# Altium schematic sheet LoRa transceiver_NOswitch.SchDoc("LoRa transceiver_NOswitch") (continued)

## Library data of the parts
A1 = MCH_000128: MCH SMT EMI Shield frame, 26.21mm X 26.21mm, 5.08 mm height; Manufacturer: Laird Technologies; ManufacturerID: Laird; Part Category: Mechanic/Shield/Solder mount socket; Part Select: SMCH0001001400010000; Supp Part number: 2497719; Supp Part number 2: 739-BMI-203-F; Active: 1; Cat1: Mechanic; Cat3: Solder mount socket; Database Table Name: _Mechanicals; Designer: Jiri Cengery; Exact price 3: 0; FIT: 0,100000001490116; Function Type: emi shielding; ID: 7920; LastRevisionNote: new release; Max Oper Temperature: 125; Min Oper Temperature: -40; PCB Status: Standard; Alternative: 1; Cat2: Shield; Component Kind: Standard; ComponentLink1Description: Datasheet; ComponentLink1URL: https://riceproject.fel.zcu.cz/Altium/PDF/Mechanic/Shields/1965028.pdf; Exact price: 1; Exact price 2: 1; LastRevisionDate: 19.8.2016; Library Name: Lib_Components_SQL.DbLib; Manuf Part Number: BMI-S-203-F; ManufacturerURL: http://www.lairdtech.com; Part Number: MCH_000128; Part type: MCH; PartNumber: MCH_000128; PartQuantity: 1; Preference: 0; Price: 42; Price 2: 19,5; Price 3: 0; Published: 19.8.2016; RoHS: 1; Simulation: nosim; Stock: 0; Supplier: Farnell; Supplier2: Mouser Electronics; Supplier3: N/A; SupplierID: Farnell; SupplierID2: Mouser; SupplierID3: no supplier; SupplierURL: http://cz.farnell.com/; SupplierURL2: http://www.mouser.com; SupplierURL3: N/A; Value: BMI-S-203-F; Publisher: Ludek Elis
A2 = MCH_000127: MCH EMI Cover Shield for BMI-S-203-F, 26.21mm X 26.21mm, 5.08 mm height,; Manufacturer: Laird Technologies; ManufacturerID: Laird; Part Category: Mechanic/Shield/Solder mount socket; Part Select: SMCH0001001400010000; Supp Part number: 2497718; Supp Part number 2: 739-BMI-203-C; Active: 1; Cat1: Mechanic; Cat3: Solder mount socket; Database Table Name: _Mechanicals; Designer: Jiri Cengery; Exact price 3: 0; FIT: 0,100000001490116; Function Type: emi shielding; ID: 7918; LastRevisionNote: new release; Max Oper Temperature: 125; Min Oper Temperature: -40; PCB Status: Mechanical; Alternative: 1; Cat2: Shield; Component Kind: Standard; ComponentLink1Description: Datasheet; ComponentLink1URL: https://riceproject.fel.zcu.cz/Altium/PDF/Mechanic/Shields/1965028.pdf; Exact price: 1; Exact price 2: 1; LastRevisionDate: 19.8.2016; Library Name: Lib_Components_SQL.DbLib; Manuf Part Number: BMI-S-203-C; ManufacturerURL: http://www.lairdtech.com; Part Number: MCH_000127; Part type: MCH; PartNumber: MCH_000127; PartQuantity: 1; Preference: 0; Price: 26,2000007629395; Price 2: 16; Price 3: 0; Published: 19.8.2016; RoHS: 1; Simulation: nosim; Stock: 0; Supplier: Farnell; Supplier2: Mouser Electronics; Supplier3: N/A; SupplierID: Farnell; SupplierID2: Mouser; SupplierID3: no supplier; SupplierURL: http://cz.farnell.com/; SupplierURL2: http://www.mouser.com; SupplierURL3: N/A; Value: BMI-S-203-C; Publisher: Ludek Elis
ANT_HF = CON_000512: CON SMA Female, pcb edge for panel, THT, 50 Ohm, 0 - 18 GHz; Manufacturer: Adam Tech; Part Select: SCON0008000000001500; Supp Part number: RF2145AT1750GHDW; Active: 1; Cat1: VF; Database Table Name: _Connectors; Design: SMA Female  edge mount; Designer: Jiri Cengery; Exact price 2: 0; ID: 7540; LastRevisionNote: new release; Max Oper Temperature: 165; Min Oper Temperature: -65; PCB Status: Electrical; Alternative: 1; Component Kind: Standard; ComponentLink1Description: Datasheet; ComponentLink1URL: https://riceproject.fel.zcu.cz/Altium/PDF/Passive/Connectors/RF2-145A-T-17-50-G-HDW.pdf; ComponentLink2Description: SMA connector; Exact price: 1; Exact price 3: 0; FIT: 0; LastRevisionDate: 11.11.2013; Library Name: Lib_Components_SQL.DbLib; Manuf Part Number: RF2-145A-T-17-50-G-HDW; ManufacturerID: Adam Tech; ManufacturerURL: http://www.adam-tech.com/index.php; Part Category: VF; Part Number: CON_000512; Part type: CON; PartNumber: CON_000512; PartQuantity: 1; Pin: 5; Preference: 0; Price: 33; Price 2: 0; Price 3: 0; Published: 29.5.2013; RoHS: 1; Simulation: nosim; Stock: 0; Supplier: TME; Supplier2: N/A; Supplier3: N/A; SupplierID: TME; SupplierID2: no supplier; SupplierID3: no supplier; SupplierURL: http://www.tme.eu/cz/; SupplierURL2: N/A; SupplierURL3: N/A; Value: RF2-145A-T-17-50-G-HDW; Publisher: Jiri Svarny
B1 = OSC_000032: Crystal - 32MHz; 10ppm, 10 pF, 80 Ohm;1.6 x 2 mm; Manuf Part Number: Q22FA12800530 FA-128 32MHZ 10PF; Manufacturer: CTS Corporation; ManufacturerID: CTS; Part Select: SOSC0003000000008320; Supp Part number: 2723228; Active: 1; Database Table Name: _Oscillators; Designer: Jiri  Cengery; Exact price 3: 0; FIT: 5; ID: 5074; LasrRevisionNote: new release; Max Oper Temperature: 70; Min Oper Temperature: -45; PCB Status: Electrical; Alternative: 1; Cat1: Crystal; Component Kind: Standard; ComponentLink1Description: Datasheet; ComponentLink1URL: https://riceproject.fel.zcu.cz/Altium/PDF/Passive/Crystal/CTS_402.pdf; Exact price: 1; Exact price 2: 1; LastRevisionDate: 17.4.2013; Library Name: Lib_Components_SQL.DbLib; ManufacturerURL: http://www.ctscorp.com/default.htm; Part Category: Crystal; Part Number: OSC_000032; Part type: OSC; PartNumber: OSC_000032; PartQuantity: 1; Preference: 0; Price: 25; Price 2: 0; Price 3: 0; Publishied: 17.4.2013; RoHS: 1; Simulation: nosim; Stock: 0; Supplier: Digikey; Supplier2: N/A; Supplier3: N/A; SupplierID: Farnell; SupplierID2: no supplier; SupplierID3: no supplier; SupplierURL: http://www.digikey.com/; SupplierURL2: N/A; SupplierURL3: N/A; Thermal Stability: 10ppm; Value: 32.00MHZ; Publisher: Ludek Elis
B2 = OSC_000031: Crystal - ABS07-32,768kHz; 12,5PF; SMD; MAX 70 kOhm; Manuf Part Number: ABS07-32.768KHZ-T; Manufacturer: Abracon; Part Select: SOSC0003000000005327; Supp Part number: 2101347; Active: 1; Database Table Name: _Oscillators; Designer: Jiri  Cengery; Exact price 3: 0; FIT: 5; ID: 4688; LasrRevisionNote: new release; Max Oper Temperature: 85; Min Oper Temperature: -45; PCB Status: Electrical; Thermal Stability: 20ppm; Alternative: 1; Cat1: Crystal; Component Kind: Standard; ComponentLink1Description: Datasheet; ComponentLink1URL: https://riceproject.fel.zcu.cz/Altium/PDF/Semiconductor/Logic/Oscilator/1883680.pdf; Exact price: 1; Exact price 2: 1; LastRevisionDate: 13.5.2011; Library Name: Lib_Components_SQL.DbLib; ManufacturerID: Abracon; ManufacturerURL: http://www.abracon.com/; Part Category: Crystal; Part Number: OSC_000031; Part type: OSC; PartNumber: OSC_000031; PartQuantity: 1; Preference: 0; Price: 15,1999998092651; Price 2: 0; Price 3: 0; Publishied: 13.5.2011; RoHS: 1; Simulation: nosim; Stock: 0; Supplier: Farnell; Supplier2: N/A; Supplier3: N/A; SupplierID: Farnell; SupplierID2: no supplier; SupplierID3: no supplier; SupplierURL: http://cz.farnell.com/; SupplierURL2: N/A; SupplierURL3: N/A; Value: 32,768kHz; Publisher: Libor Polacek
C1 C2 C27 C33 = CAP_000643: CAP CER 15pF 50V NPO 5% 0402; Manuf Part Number: CC0402JRNPO9BN150; Manufacturer: Yageo; Part Category: Ceramic/0402/COG/NPO; Part Select: SCAP0001000200012150; Supp Part number: 3019172; Active: 1; Cat1: Ceramic; Cat2: 0402; Cat3: COG/NPO; Database Table Name: _Capacitors; Designer: Jiri Cengery; Exact price 2: 0; FIT: 0,100000001490116; ID: 7910; LasrRevisionNote: new release; Max Oper Temperature: 125; Min Oper Temperature: -55; PCB Status: Electrical; Alternative: 1; Component Kind: Standard; ComponentLink1Description: Datasheet; ComponentLink1URL: https://riceproject.fel.zcu.cz/Altium/PDF/Passive/Capacitors/2213336.pdf; Dielectric: NPO; Exact price: 1; Exact price 3: 0; LastRevisionDate: 11.5.2011; Library Name: Lib_Components_SQL.DbLib; ManufacturerID: Yageo; ManufacturerURL: http://www.yageo.com/portal/index.jsp; Part Number: CAP_000643; Part type: CAP; PartNumber: CAP_000643; PartQuantity: 1; Preference: 0; Price: 0,519999980926514; Price 2: 0; Price 3: 0; Publishied: 11.5.2011; RoHS: 1; Simulation: cap; Stock: 0; Supplier: Farnell; Supplier2: N/A; Supplier3: N/A; SupplierID: Farnell; SupplierID2: no supplier; SupplierID3: no supplier; SupplierURL: http://cz.farnell.com/; SupplierURL2: N/A; SupplierURL3: N/A; Tolerance: 5%; Value: 15.0p; Voltage: 50V; Publisher: Libor Polacek
C3-C5 C20 C22 C25 C26 C28 C30-C32 = CAP_000417: CAP CER 100nF 25V X7R 10% 0402; Manuf Part Number: C1005X7R1E104K; Manufacturer: TDK Corporation; ManufacturerID: TDK; Part Category: Ceramic/0402/X7R; Part Select: SCAP0001000200026100; Supp Part number: 445-7348-1-ND; Active: 1; Cat1: Ceramic; Cat2: 0402; Database Table Name: _Capacitors; Designer: Libor Polacek; Exact price 2: 0; FIT: 0,100000001490116; ID: 2416; LasrRevisionNote: new release; Max Oper Temperature: 125; Min Oper Temperature: -55; PCB Status: Electrical; Preference: 100; Alternative: 1; Cat3: X7R; Component Kind: Standard; ComponentLink1Description: Datasheet; ComponentLink1URL: https://riceproject.fel.zcu.cz/Altium/PDF/passive/capacitors/Cxxxx_TDK.pdf; Dielectric: X7R; Exact price: 1; Exact price 3: 0; LastRevisionDate: 11.5.2011; Library Name: Lib_Components_SQL.DbLib; ManufacturerURL: http://www.tdk.com/; Part Number: CAP_000417; Part type: CAP; PartNumber: CAP_000417; PartQuantity: 1; PCB3D: sm_cap_0402; Price: 0,349999994039536; Price 2: 0; Price 3: 0; Publishied: 11.5.2011; RoHS: 1; Simulation: cap; Stock: 3064; Supplier: Digikey; Supplier2: N/A; Supplier3: N/A; SupplierID: Digikey; SupplierID2: no supplier; SupplierID3: no supplier; SupplierURL: http://www.digikey.com/; SupplierURL2: N/A; SupplierURL3: N/A; Tolerance: 10%; Value: 100n; Voltage: 25V; Publisher: Libor Polacek
C6 C29 C44 = CAP_000560: CAP CER 1.0uF 16V X7R 20% 0603; Manuf Part Number: 0603YC105MAT2A; Manufacturer: AVX; Part Category: Ceramic/0603/X7R; Part Select: SCAP0001000300027100; Supp Part number: 581-0603YC105MAT2A; Active: 1; Cat1: Ceramic; Cat2: 0603; Database Table Name: _Capacitors; Designer: Libor Polacek; Exact price 2: 0; FIT: 0,100000001490116; ID: 6238; LastRevisionNote: new release; Max Oper Temperature: 125; Min Oper Temperature: -55; PCB Status: Electrical; Alternative: 1; Cat3: X7R; Component Kind: Standard; ComponentLink1Description: Datasheet; ComponentLink1URL: https://riceproject.fel.zcu.cz/Altium/PDF/Passive/Capacitors/X7RDielectric-777024a.pdf; Dielectric: X7R; Exact price: 1; Exact price 3: 0; LastRevisionDate: 11.5.2011; Library Name: Lib_Components_SQL.DbLib; ManufacturerID: AVX; ManufacturerURL: http://www.avx.com/; Part Number: CAP_000560; Part type: CAP; PartNumber: CAP_000560; PartQuantity: 1; PCB3D: sm_cap_0603; Preference: 0; Price: 1,12000000476837; Price 2: 0; Price 3: 0; Published: 11.5.2011; RoHS: 1; Simulation: cap; Stock: 196; Supplier: Mouser Electronics; Supplier2: N/A; Supplier3: N/A; SupplierID: Mouser; SupplierID2: no supplier; SupplierID3: no supplier; SupplierURL: http://www.mouser.com; SupplierURL2: N/A; SupplierURL3: N/A; Tolerance: 20%; Value: 1.00u; Publisher: Libor Polacek
  C6 C29: Voltage: 16V
C7 C8 C16-C18 C21 = CAP_000513: CAP CER 47.0pF 50V COG 1% 0402; Manuf Part Number: GRM1555C1H470FA01D; Manufacturer: Murata; Part Category: Ceramic/0402/COG/NPO; Part Select: SCAP0001000200012220; Supp Part number: 490-6241-1-ND; Active: 1; Cat1: Ceramic; Cat2: 0402; Cat3: COG/NPO; Database Table Name: _Capacitors; Designer: Jiri  Cengery; Exact price 2: 0; FIT: 0,100000001490116; ID: 5076; LasrRevisionNote: new release; Max Oper Temperature: 125; Min Oper Temperature: -55; PCB Status: Electrical; Alternative: 1; Component Kind: Standard; ComponentLink1Description: Datasheet; ComponentLink1URL: https://riceproject.fel.zcu.cz/Altium/PDF/Passive/Capacitors/mlcc_commercial_general_en.pdf; Dielectric: COG; Exact price: 1; Exact price 3: 0; LastRevisionDate: 11.5.2011; Library Name: Lib_Components_SQL.DbLib; ManufacturerID: Murata; ManufacturerURL: http://www.murata.com/; Part Number: CAP_000513; Part type: CAP; PartNumber: CAP_000513; PartQuantity: 1; PCB3D: sm_cap_0402; Preference: 0; Price: 3; Price 2: 0; Price 3: 0; Publishied: 11.5.2011; RoHS: 1; Simulation: cap; Stock: 0; Supplier: Digikey; Supplier2: N/A; Supplier3: N/A; SupplierID: Digikey; SupplierID2: no supplier; SupplierID3: no supplier; SupplierURL: http://www.digikey.com/; SupplierURL2: N/A; SupplierURL3: N/A; Tolerance: 1%; Value: 47.0p; Voltage: 50V; Publisher: Libor Polacek
C9 = CAP_000642: CAP CER 33pF 50V NPO 5% 0402; Manuf Part Number: CC0402JRNPO9BN330; Manufacturer: Yageo; Part Category: Ceramic/0402/COG/NPO; Part Select: SCAP0001000200012330; Supp Part number: 3019196; Active: 1; Cat1: Ceramic; Cat2: 0402; Cat3: COG/NPO; Database Table Name: _Capacitors; Designer: Jiri Cengery; Exact price 2: 0; FIT: 0,100000001490116; ID: 7906; LasrRevisionNote: new release; Max Oper Temperature: 125; Min Oper Temperature: -55; PCB Status: Electrical; Alternative: 1; Component Kind: Standard; ComponentLink1Description: Datasheet; ComponentLink1URL: https://riceproject.fel.zcu.cz/Altium/PDF/Passive/Capacitors/2213336.pdf; Dielectric: NPO; Exact price: 1; Exact price 3: 0; LastRevisionDate: 11.5.2011; Library Name: Lib_Components_SQL.DbLib; ManufacturerID: Yageo; ManufacturerURL: http://www.yageo.com/portal/index.jsp; Part Number: CAP_000642; Part type: CAP; PartNumber: CAP_000642; PartQuantity: 1; Preference: 0; Price: 0,52999997138977; Price 2: 0; Price 3: 0; Publishied: 11.5.2011; RoHS: 1; Simulation: cap; Stock: 0; Supplier: Farnell; Supplier2: N/A; Supplier3: N/A; SupplierID: Farnell; SupplierID2: no supplier; SupplierID3: no supplier; SupplierURL: http://cz.farnell.com/; SupplierURL2: N/A; SupplierURL3: N/A; Tolerance: 5%; Value: 33.0p; Voltage: 50V; Publisher: Libor Polacek
C10 = CAP_000455: CAP CER 1.5pF 50V COG ±0.25pF 0402; Manuf Part Number: C1005C0G1H1R5C; Manufacturer: TDK Corporation; ManufacturerID: TDK; Part Category: Ceramic/0402/COG/NPO; Part Select: SCAP0001000200011150; Supp Part number: 445-4859-1-ND; Active: 1; Cat1: Ceramic; Cat2: 0402; Cat3: COG/NPO; Database Table Name: _Capacitors; Designer: Lukas Pusman; Exact price 2: 0; FIT: 0,100000001490116; ID: 3175; LasrRevisionNote: new release; Max Oper Temperature: 125; Min Oper Temperature: -55; PCB Status: Electrical; Preference: 100; Alternative: 1; Component Kind: Standard; ComponentLink1Description: Datasheet; ComponentLink1URL: https://riceproject.fel.zcu.cz/Altium/PDF/passive/capacitors/Cxxxx_TDK.pdf; Dielectric: COG; Exact price: 1; Exact price 3: 0; LastRevisionDate: 11.5.2011; Library Name: Lib_Components_SQL.DbLib; ManufacturerURL: http://www.tdk.com/; Part Number: CAP_000455; Part type: CAP; PartNumber: CAP_000455; PartQuantity: 1; PCB3D: sm_cap_0402; Price: 3; Price 2: 0; Price 3: 0; Publishied: 11.5.2011; RoHS: 1; Simulation: cap; Stock: 0; Supplier: Digikey; Supplier2: N/A; Supplier3: N/A; SupplierID: Digikey; SupplierID2: no supplier; SupplierID3: no supplier; SupplierURL: http://www.digikey.com/; SupplierURL2: N/A; SupplierURL3: N/A; Tolerance: ±0.25pF; Value: 1.5p; Voltage: 50V; Publisher: Libor Polacek
C11 C14 = CAP_000639: CAP CER 4.7pF 50V NPO ±0,25p 0402; Manuf Part Number: CC0402CRNPO9BN4R7; Manufacturer: Yageo; Part Category: Ceramic/0402/COG/NPO; Part Select: SCAP0001000200011470; Supp Part number: 3019147; Active: 1; Cat1: Ceramic; Cat2: 0402; Cat3: COG/NPO; Database Table Name: _Capacitors; Designer: Jiri Cengery; Exact price 2: 0; FIT: 0,100000001490116; ID: 7900; LasrRevisionNote: new release; Max Oper Temperature: 125; Min Oper Temperature: -55; PCB Status: Electrical; Tolerance: 0,25pF; Alternative: 1; Component Kind: Standard; ComponentLink1Description: Datasheet; ComponentLink1URL: https://riceproject.fel.zcu.cz/Altium/PDF/Passive/Capacitors/2213336.pdf; Dielectric: NPO; Exact price: 1; Exact price 3: 0; LastRevisionDate: 11.5.2011; Library Name: Lib_Components_SQL.DbLib; ManufacturerID: Yageo; ManufacturerURL: http://www.yageo.com/portal/index.jsp; Part Number: CAP_000639; Part type: CAP; PartNumber: CAP_000639; PartQuantity: 1; Preference: 0; Price: 0,439999997615814; Price 2: 0; Price 3: 0; Publishied: 11.5.2011; RoHS: 1; Simulation: cap; Stock: 0; Supplier: Farnell; Supplier2: N/A; Supplier3: N/A; SupplierID: Farnell; SupplierID2: no supplier; SupplierID3: no supplier; SupplierURL: http://cz.farnell.com/; SupplierURL2: N/A; SupplierURL3: N/A; Value: 4.70p; Voltage: 50V; Publisher: Libor Polacek
C12 = Cap_000641: CAP CER 1.2pF 50V NPO ± 0,25pF 0402; Manuf Part Number: CC0402CRNPO9BN1R2; Manufacturer: Yageo; Part Category: Ceramic/0402/COG/NPO; Part Select: SCAP0001000200011120; Supp Part number: 3369075; Active: 1; Cat1: Ceramic; Cat2: 0402; Cat3: COG/NPO; Database Table Name: _Capacitors; Designer: Jiri Cengery; Exact price 2: 0; FIT: 0,100000001490116; ID: 7904; LasrRevisionNote: new release; Max Oper Temperature: 125; Min Oper Temperature: -55; PCB Status: Electrical; Tolerance: 0,25pF; Alternative: 1; Component Kind: Standard; ComponentLink1Description: Datasheet; ComponentLink1URL: https://riceproject.fel.zcu.cz/Altium/PDF/Passive/Capacitors/2213336.pdf; Dielectric: NPO; Exact price: 1; Exact price 3: 0; LastRevisionDate: 11.5.2011; Library Name: Lib_Components_SQL.DbLib; ManufacturerID: Yageo; ManufacturerURL: http://www.yageo.com/portal/index.jsp; Part Number: CAP_000641; Part type: CAP; PartNumber: CAP_000641; PartQuantity: 1; Preference: 0; Price: 0,439999997615814; Price 2: 0; Price 3: 0; Publishied: 11.5.2011; RoHS: 1; Simulation: cap; Stock: 0; Supplier: Farnell; Supplier2: N/A; Supplier3: N/A; SupplierID: Farnell; SupplierID2: no supplier; SupplierID3: no supplier; SupplierURL: http://cz.farnell.com/; SupplierURL2: N/A; SupplierURL3: N/A; Value: 1.20p; Voltage: 50V; Publisher: Libor Polacek
C13 C15 = CAP_000640: CAP CER 1.8pF 50V NPO ± 0,25pF 0402; Manuf Part Number: CC0402CRNPO9BN1R8; Manufacturer: Yageo; Part Category: Ceramic/0402/COG/NPO; Part Select: SCAP0001000200011180; Supp Part number: 3369076; Active: 1; Cat1: Ceramic; Cat2: 0402; Cat3: COG/NPO; Database Table Name: _Capacitors; Designer: Jiri Cengery; Exact price 2: 0; FIT: 0,100000001490116; ID: 7902; LasrRevisionNote: new release; Max Oper Temperature: 125; Min Oper Temperature: -55; PCB Status: Electrical; Tolerance: 0,25pF; Alternative: 1; Component Kind: Standard; ComponentLink1Description: Datasheet; ComponentLink1URL: https://riceproject.fel.zcu.cz/Altium/PDF/Passive/Capacitors/2213336.pdf; Dielectric: NPO; Exact price: 1; Exact price 3: 0; LastRevisionDate: 11.5.2011; Library Name: Lib_Components_SQL.DbLib; ManufacturerID: Yageo; ManufacturerURL: http://www.yageo.com/portal/index.jsp; Part Number: CAP_000640; Part type: CAP; PartNumber: CAP_000640; PartQuantity: 1; Preference: 0; Price: 0,5799999833107; Price 2: 0; Price 3: 0; Publishied: 11.5.2011; RoHS: 1; Simulation: cap; Stock: 0; Supplier: Farnell; Supplier2: N/A; Supplier3: N/A; SupplierID: Farnell; SupplierID2: no supplier; SupplierID3: no supplier; SupplierURL: http://cz.farnell.com/; SupplierURL2: N/A; SupplierURL3: N/A; Value: 1.80p; Voltage: 50V; Publisher: Libor Polacek
C19 = CAP_000514: CAP CER 6.8pF 50V COG 0.5% 0402; Manuf Part Number: GCM1555C1H6R8DA16D; Manufacturer: Murata; Part Category: Ceramic/0402/COG/NPO; Part Select: SCAP0001000200012470; Supp Part number: 490-13293-1-ND; Active: 1; Cat1: Ceramic; Cat2: 0402; Cat3: COG/NPO; Database Table Name: _Capacitors; Designer: Jiri  Cengery; Exact price 2: 0; FIT: 0,100000001490116; ID: 5078; LasrRevisionNote: new release; Max Oper Temperature: 125; Min Oper Temperature: -55; PCB Status: Electrical; Alternative: 1; Component Kind: Standard; ComponentLink1Description: Datasheet; ComponentLink1URL: https://riceproject.fel.zcu.cz/Altium/PDF/Passive/Capacitors/c03e.pdf; Dielectric: COG; Exact price: 1; Exact price 3: 0; LastRevisionDate: 11.5.2011; Library Name: Lib_Components_SQL.DbLib; ManufacturerID: Murata; ManufacturerURL: http://www.murata.com/; Part Number: CAP_000514; Part type: CAP; PartNumber: CAP_000514; PartQuantity: 1; PCB3D: sm_cap_0402; Preference: 0; Price: 0; Price 2: 0; Price 3: 0; Publishied: 11.5.2011; RoHS: 1; Simulation: cap; Stock: 0; Supplier: Digikey; Supplier2: N/A; Supplier3: N/A; SupplierID: Digikey; SupplierID2: no supplier; SupplierID3: no supplier; SupplierURL: http://www.digikey.com/; SupplierURL2: N/A; SupplierURL3: N/A; Tolerance: 0.5%; Value: 6.8p; Voltage: 50V; Publisher: Libor Polacek
C23 C24 = CAP_000625: CAP CER 10.0u 10V X6S 20% 0603; Manuf Part Number: CL10X106MP8NRNC; Manufacturer: Samsung Electro-Mechanics; ManufacturerID: Samsung; Part Category: Ceramic/0603/X5R; Part Select: SCAP0001000300038100; Supp Part number: 1276-2383-1-ND; Active: 1; Cat1: Ceramic; Cat2: 0603; Cat3: X5R; Database Table Name: _Capacitors; Designer: Martin Jára; Exact price 2: 0; FIT: 0,100000001490116; ID: 7716; LasrRevisionNote: new release; Max Oper Temperature: 105; Min Oper Temperature: -55; PCB Status: Electrical; Alternative: 1; Component Kind: Standard; ComponentLink1Description: Datasheet; ComponentLink1URL: https://riceproject.fel.zcu.cz/Altium/PDF/Passive/Capacitors/MLCC(1).pdf; Dielectric: X6S; Exact price: 1; Exact price 3: 0; LastRevisionDate: 11.5.2011; Library Name: Lib_Components_SQL.DbLib; ManufacturerURL: http://www.samsungsem.com; Part Number: CAP_000625; Part type: CAP; PartNumber: CAP_000625; PartQuantity: 1; PCB3D: sm_cap_0603; Preference: 0; Price: 9; Price 2: 0; Price 3: 0; Publishied: 11.5.2011; RoHS: 1; Simulation: cap; Stock: 0; Supplier: Digikey; Supplier2: N/A; Supplier3: N/A; SupplierID: Digikey; SupplierID2: no supplier; SupplierID3: no supplier; SupplierURL: http://www.digikey.com/; SupplierURL2: N/A; SupplierURL3: N/A; Tolerance: 20%; Value: 10.0u; Voltage: 10V; Publisher: Libor Polacek
C36 = CAP_000450: CAP CER 10.0nF 25V X7R 10% 0402; Manuf Part Number: C1005X7R1E103K; Manufacturer: TDK Corporation; ManufacturerID: TDK; Part Category: Ceramic/0402/X7R; Part Select: SCAP0001000200026100; Supp Part number: 445-1260-1-ND; Active: 1; Cat1: Ceramic; Cat2: 0402; Database Table Name: _Capacitors; Designer: Libor Polacek; Exact price 2: 0; FIT: 0,100000001490116; ID: 3141; LasrRevisionNote: new release; Max Oper Temperature: 125; Min Oper Temperature: -55; PCB Status: Electrical; Preference: 100; Alternative: 1; Cat3: X7R; Component Kind: Standard; ComponentLink1Description: Datasheet; ComponentLink1URL: https://riceproject.fel.zcu.cz/Altium/PDF/passive/capacitors/Cxxxx_TDK.pdf; Dielectric: X7R; Exact price: 1; Exact price 3: 0; LastRevisionDate: 11.5.2011; Library Name: Lib_Components_SQL.DbLib; ManufacturerURL: http://www.tdk.com/; Part Number: CAP_000450; Part type: CAP; PartNumber: CAP_000450; PartQuantity: 1; PCB3D: sm_cap_0402; Price: 0,300000011920929; Price 2: 0; Price 3: 0; Publishied: 11.5.2011; RoHS: 1; Simulation: cap; Stock: 0; Supplier: Digikey; Supplier2: N/A; Supplier3: N/A; SupplierID: Digikey; SupplierID2: no supplier; SupplierID3: no supplier; SupplierURL: http://www.digikey.com/; SupplierURL2: N/A; SupplierURL3: N/A; Tolerance: 10%; Value: 10.0n; Voltage: 25V; Publisher: Libor Polacek
D1 D2 = OPT_000081: OPT LED red 625nm 2mA 50mcd 1.8V 0603; Manufacturer: kingbright; Part Category: LED/0603; Part Select: SOPT0001000100000000; Supp Part number: 2610411; Active: 1; Cat2: 0603; Database Table Name: _Optoelectronics; Designer: Jiri  Cengery; Exact price: 0; FIT: 2; ID: 6052; LastRevisionNote: new release; Max Oper Temperature: 85; Min Oper Temperature: -40; PCB Status: Electrical; Alternative: 1; Cat1: LED; Component Kind: Standard; ComponentLink1Description: Datasheet; ComponentLink1URL: https://riceproject.fel.zcu.cz/Altium/PDF/Semiconductor\Analog\LED\2068425.pdf; Exact price 2: 0; Exaxt price 3: 0; LastRevisionDate: 11.5.2011; Library Name: Lib_Components_SQL.DbLib; Manuf Part Number: KPTD-1608LVSECK-J3-PF; ManufacturerID: Kingbright; ManufacturerURL: www.kingbright.com; Part Number: OPT_000081; Part type: OPT; PartNumber: OPT_000081; PartQuantity: 1; PCB3D: sm_opt_led0603_h37_opp; Preference: 0; Price: 7; Price 2: 0; Price 3: 0; Published: 11.5.2011; Simulation: nosim; Stock: 0; Supplier: Farnell; Supplier2: N/A; Supplier3: N/A; SupplierID: Farnell; SupplierID2: no supplier; SupplierID3: no supplier; SupplierURL: http://cz.farnell.com/; SupplierURL2: N/A; SupplierURL3: N/A; Value: KPTD-1608LVSECK-J3-PF; Publisher: Libor Polacek
FID1-FID4 = MCH_000050: Fiducial local circle 0.8mm; Manuf Part Number: x; ManufacturerID: no manufacturer; Part Category: Virtual/Fiducial; Part Select: SMCH0002000200000000; Active: 1; Cat1: Virtual; Database Table Name: _Mechanicals; Designer: Libor Polacek; Exact price: 0; ID: 2608; LastRevisionNote: new release; Max Oper Temperature: 200; Min Oper Temperature: -60; Alternative: 1; Cat2: Fiducial; Component Kind: Standard; ComponentLink1Description: Datasheet; Exact price 2: 0; Exact price 3: 0; FIT: 0; Function Type: fiducial; LastRevisionDate: 8.9.2011; Library Name: Lib_Components_SQL.DbLib; Part Number: MCH_000050; Part type: MCH; PartNumber: MCH_000050; PartQuantity: 1; PCB3D: sm_vir_fiducial; PCB Status: Virtual; Preference: 0; Price: 0; Price 2: 0; Price 3: 0; Publishied: 8.9.2011; RoHS: 1; Simulation: nosim; Stock: 0; Supp Part number: x; Supplier: N/A; Supplier2: N/A; Supplier3: N/A; SupplierID: no supplier; SupplierID2: no supplier; SupplierID3: no supplier; SupplierURL: N/A; SupplierURL2: N/A; SupplierURL3: N/A; Value: Fiducial; Publisher: Libor Polacek
H1 = MCH_000066: Test 5 pins row pit 50; ManufacturerID: no manufacturer; Part Category: Virtual/Test point; Part Select: SMCH0002000100000000; Active: 1; Cat1: Virtual; Cat2: Test point; Database Table Name: _Mechanicals; Designer: Jiri  Cengery; Exact price 2: 0; FIT: 9,99999974737875E-6; Function Type: Test points; ID: 3861; LastRevisionNote: new release; Max Oper Temperature: 200; Min Oper Temperature: -60; Alternative: 1; ComponentLink1Description: Datasheet; Exact price: 1; Exact price 3: 0; LastRevisionDate: 29.2.2016; Library Name: Lib_Components_SQL.DbLib; Part Number: MCH_000066; Part type: MCH; PartNumber: MCH_000066; PartQuantity: 1; PCB Status: Virtual; Preference: 0; Price: 0; Price 2: 0; Price 3: 0; Publishied: 29.2.2016; RoHS: 1; Simulation: nosim; Stock: 0; Supplier: N/A; Supplier2: N/A; Supplier3: N/A; SupplierID: no supplier; SupplierID2: no supplier; SupplierID3: no supplier; SupplierURL: N/A; SupplierURL2: N/A; SupplierURL3: N/A; Value: TP40_5_pit50; Publisher: Jiri Cengery
H2 = CON_000361: CON Receptacle 1x10 100 mils, leads length 10mm; Manufacturer: SAMTEC; Part Category: Pin rows/Receptacle/long leads; Part Select: TCON0001000200022120; Supp Part number: 2283784; Active: 1; Cat1: Pin rows; Cat3: long leads; Database Table Name: _Connectors; Design: RECEPTACLE 100mill; Designer: Jiri  Cengery; Exact price 2: 0; FIT: 50; ID: 5578; Max Oper Temperature: 125; Min Oper Temperature: -55; PCB Status: Electrical; System: Connector; Alternative: 1; Cat2: Receptacle; Component Kind: Standard; ComponentLink1Description: Datasheet; ComponentLink1URL: https://riceproject.fel.zcu.cz/Altium/PDF/Passive\Connectors\Samtec\1680445_.pdf; Exact price: 1; Exaxt price 3: 0; LatestRevisionDate: 13.10.2017; LatestRevisionNote: New Release; Library Name: Lib_Components_SQL.DbLib; Manuf Part Number: SSQ-112-03-F-S; ManufacturerID: SAMTEC; ManufacturerURL: http://www.samtec.com/; Part Number: CON_000361; Part type: CON; PartNumber: CON_000361; PartQuantity: 1; PCB3D: TH_CON_REC1x12_PIT100_L400; Pin: 12; Preference: 0; Price: 54; Price 2: 0; Price 3: 0; Published: 13.10.2017; ROHS: 1; Signal Integrity: Connector; Simulation: nosim; Stock: 0; Supplier: Farnell; Supplier2: N/A; Supplier3: N/A; SupplierID: Farnell; SupplierID2: no supplier; SupplierID3: no supplier; SupplierURL: http://cz.farnell.com/; SupplierURL2: N/A; SupplierURL3: N/A; Value: SSQ-112-03-F-S; Publisher: Jiri Cengery
H3 = CON_000370: CON Receptacle 1x8 100 mils, hight 8.5mm; Manufacturer: MCM Electronics; ManufacturerID: Multicomp; Part Category: Pin rows/Receptacle; Part Select: TCON0001000200001800; Supp Part number: 1593463; Active: 1; Cat1: Pin rows; Database Table Name: _Connectors; Design: RECEPTACLE 100mill; Designer: Jiri  Cengery; Exact price 2: 0; FIT: 50; ID: 5624; Max Oper Temperature: 105; Min Oper Temperature: -55; PCB Status: Electrical; System: Connector; Alternative: 1; Cat2: Receptacle; Component Kind: Standard; ComponentLink1Description: Datasheet; ComponentLink1URL: https://riceproject.fel.zcu.cz/Altium/PDF/Passive\Connectors\2290158.pdf; Exact price: 1; Exaxt price 3: 0; LatestRevisionDate: 13.10.2017; LatestRevisionNote: New Release; Library Name: Lib_Components_SQL.DbLib; Manuf Part Number: 2212S-08SG-85; ManufacturerURL: http://www.mcmelectronics.com; Part Number: CON_000370; Part type: CON; PartNumber: CON_000370; PartQuantity: 1; PCB3D: TH_CON_REC1x8_PIT100; Pin: 8; Preference: 0; Price: 23; Price 2: 0; Price 3: 0; Published: 13.10.2017; ROHS: 1; Signal Integrity: Connector; Simulation: nosim; Stock: 0; Supplier: Farnell; Supplier2: N/A; Supplier3: N/A; SupplierID: Farnell; SupplierID2: no supplier; SupplierID3: no supplier; SupplierURL: http://cz.farnell.com/; SupplierURL2: N/A; SupplierURL3: N/A; Value: 2212S-08SG-85; Publisher: Jiri Cengery
L1 = EMI_000000: EMI 0603 1000R/ 100MHz 300mA 0,6R; Manuf Part Number: 742792664; Manufacturer: Würth Elektronik; ManufacturerID: Wurth; Part Select: SEMI0001000000003000; Supp Part number: 732-1586-2-ND; Active: 1; Cat1: Inductive; Database Table Name: _EMI_filters; DC Current: 300m; Designer: Tomáš Košan; FIT: 0,100000001490116; ID: 878; LasrRevisionNote: new release; Max Oper Temperature: 125; Min Oper Temperature: -55; PCB Status: Electrical; Preference: 0; Resistance: 1000; Alternative: 1; Component Kind: Standard; ComponentLink1Description: Datasheet; ComponentLink1URL: https://riceproject.fel.zcu.cz/Altium/PDF/Passive/Inductors/Ferite bead/0603_1000R_300mA.pdf; Exact price: 1; LastRevisionDate: 11.5.2011; Library Name: Lib_Components_SQL.DbLib; ManufacturerURL: http://www.we-online.com/web/en/wuerth_elektronik/start.php; Part Category: Inductive; Part Number: EMI_000000; Part type: EMI; PartNumber: EMI_000000; PartQuantity: 1; PCB3D: sm_emi_0603; Price: 0,930000007152557; Price 2: 0; Price 3: 0; Publishied: 11.5.2011; Quantity: 1; RoHS: 1; Simulation: emi; Stock: 122; Supplier: Digikey; Supplier2: N/A; Supplier3: N/A; SupplierID: Digikey; SupplierID2: no supplier; SupplierID3: no supplier; SupplierURL: http://www.digikey.com/; SupplierURL2: N/A; SupplierURL3: N/A; Value: 1000R; Publisher: Libor Polacek
L2 = IND_000124: IND 33nH 260mA, wire, 0402; Manuf Part Number: LQW15AN33NJ00D; Manufacturer: Murata; Part Category: Unshielded/Standard; Part Select: SIND0002000100002330; Supp Part number: 2840161; Active: 1; Cat1: Unshielded; Cat2: Standard; Database Table Name: _Inductors; Designer: Jiri Cengery; Exact price 2: 0; FIT: 2; Frequency: 3.2GHz; ID: 7886; LasrRevisionNote: new release; Max Oper Temperature: 125; Min Oper Temperature: -55; PCB Status: Electrical; Resistance: 630m; Tolerance: 5%; Alternative: 1; Component Kind: Standard; ComponentLink1Description: Datasheet; ComponentLink1URL: https://riceproject.fel.zcu.cz/Altium/PDF/Passive/Inductors/2575540.pdf; DC Current: 260mA; Exact price: 1; Exact price 3: 0; LastRevisionDate: 11.5.2011; Library Name: Lib_Components_SQL.DbLib; ManufacturerID: Murata; ManufacturerURL: http://www.murata.com/; Part Number: IND_000124; Part type: IND; PartNumber: IND_000124; PartQuantity: 1; Preference: 0; Price: 3; Price 2: 0; Price 3: 0; Publishied: 11.5.2011; RoHS: 1; Simulation: nosim; Stock: 0; Supplier: Farnell; Supplier2: N/A; Supplier3: N/A; SupplierID: Farnell; SupplierID2: no supplier; SupplierID3: no supplier; SupplierURL: http://cz.farnell.com/; SupplierURL2: N/A; SupplierURL3: N/A; Value: 33.0n; Publisher: Libor Polacek
L3 = IND_000052: IND 5.6nH 300mA 200 mohm Multilayer 0402; Manuf Part Number: LQG15HS5N6S02D; Manufacturer: Murata; Part Category: Unshielded/Standard; Part Select: SIND0002000100001200; Supp Part number: 490-2619-1-ND; Active: 1; Cat1: Unshielded; Cat2: Standard; Database Table Name: _Inductors; Designer: Jiri  Cengery; Exact price 2: 0; FIT: 2; Frequency: 4.5GHz; ID: 3645; LasrRevisionNote: new release; Max Oper Temperature: 125; Min Oper Temperature: -55; PCB Status: Electrical; Resistance: 200m; Tolerance: ±0.3nH; Alternative: 1; Component Kind: Standard; ComponentLink1Description: Datasheet; ComponentLink1URL: https://riceproject.fel.zcu.cz/Altium/PDF/Passive/Inductors/L0075S0083LQG15HS.pdf; DC Current: 300mA; Exact price: 1; Exact price 3: 0; LastRevisionDate: 11.5.2011; Library Name: Lib_Components_SQL.DbLib; ManufacturerID: Murata; ManufacturerURL: http://www.murata.com/; Part Number: IND_000052; Part type: IND; PartNumber: IND_000052; PartQuantity: 1; Preference: 0; Price: 0,100000001490116; Price 2: 0; Price 3: 0; Publishied: 11.5.2011; RoHS: 1; Simulation: nosim; Stock: 0; Supplier: Digikey; Supplier2: N/A; Supplier3: N/A; SupplierID: Digikey; SupplierID2: no supplier; SupplierID3: no supplier; SupplierURL: http://www.digikey.com/; SupplierURL2: N/A; SupplierURL3: N/A; Value: 5.6n; Publisher: Libor Polacek
L4 = IND_000122: IND 10nH 500mA chip 0402; Manuf Part Number: LQG15HS10NJ02D; Manufacturer: Murata; Part Category: Unshielded/Standard; Part Select: SIND0002000100002100; Supp Part number: 2840111; Active: 1; Cat1: Unshielded; Cat2: Standard; Database Table Name: _Inductors; Designer: Jiri Cengery; Exact price 2: 0; FIT: 2; Frequency: 3.4GHz; ID: 7882; LasrRevisionNote: new release; Max Oper Temperature: 125; Min Oper Temperature: -55; PCB Status: Electrical; Resistance: 260m; Tolerance: 5%; Alternative: 1; Component Kind: Standard; ComponentLink1Description: Datasheet; ComponentLink1URL: https://riceproject.fel.zcu.cz/Altium/PDF/Passive/Inductors/2575533.pdf; DC Current: 500mA; Exact price: 1; Exact price 3: 0; LastRevisionDate: 11.5.2011; Library Name: Lib_Components_SQL.DbLib; ManufacturerID: Murata; ManufacturerURL: http://www.murata.com/; Part Number: IND_000122; Part type: IND; PartNumber: IND_000122; PartQuantity: 1; Preference: 0; Price: 1; Price 2: 0; Price 3: 0; Publishied: 11.5.2011; RoHS: 1; Simulation: nosim; Stock: 0; Supplier: Farnell; Supplier2: N/A; Supplier3: N/A; SupplierID: Farnell; SupplierID2: no supplier; SupplierID3: no supplier; SupplierURL: http://cz.farnell.com/; SupplierURL2: N/A; SupplierURL3: N/A; Value: 10n; Publisher: Libor Polacek
L5 = IND_000123: IND 6,2nH 600mA chip 0402; Manuf Part Number: LQG15HS6N2S02D; Manufacturer: Murata; Part Category: Unshielded/Standard; Part Select: SIND0002000100001620; Supp Part number: 3471248; Active: 1; Cat1: Unshielded; Cat2: Standard; Database Table Name: _Inductors; Designer: Jiri Cengery; Exact price 2: 0; FIT: 2; Frequency: 4.5GHz; ID: 7884; LasrRevisionNote: new release; Max Oper Temperature: 125; Min Oper Temperature: -55; PCB Status: Electrical; Resistance: 200m; Tolerance: 0.3nH; Alternative: 1; Component Kind: Standard; ComponentLink1Description: Datasheet; ComponentLink1URL: https://riceproject.fel.zcu.cz/Altium/PDF/Passive/Inductors/3006695.pdf; DC Current: 600mA; Exact price: 1; Exact price 3: 0; LastRevisionDate: 11.5.2011; Library Name: Lib_Components_SQL.DbLib; ManufacturerID: Murata; ManufacturerURL: http://www.murata.com/; Part Number: IND_000123; Part type: IND; PartNumber: IND_000123; PartQuantity: 1; Preference: 0; Price: 0,800000011920929; Price 2: 0; Price 3: 0; Publishied: 11.5.2011; RoHS: 1; Simulation: nosim; Stock: 0; Supplier: Farnell; Supplier2: N/A; Supplier3: N/A; SupplierID: Farnell; SupplierID2: no supplier; SupplierID3: no supplier; SupplierURL: http://cz.farnell.com/; SupplierURL2: N/A; SupplierURL3: N/A; Value: 6.20n; Publisher: Libor Polacek
L6 = RES_000578: RES 0402 0.00R SMD thick film; Manuf Part Number: ERJ-2GE0R00X; Manufacturer: Panasonic; Part Category: Thick film/0402; Part Select: SRES0001000200003100; Supp Part number: P0.0JCT-ND; Active: 1; Cat2: 0402; Database Table Name: _Resistors; DC Current: 600mA; Designer: Libor Polacek; Exact price 2: 0; FIT: 0,800000011920929; Frequency: 4.5GHz; ID: 2972; LasrRevisionNote: new release; Max Oper Temperature: 125; Min Oper Temperature: -55; PCB Status: Electrical; Resistance: 200m; Tolerance: 0.3nH; Power: 100mW; Alternative: 1; Cat1: Thick film; Component Kind: Standard; ComponentLink1Description: Datasheet; ComponentLink1URL: https://riceproject.fel.zcu.cz/Altium/PDF/Passive/Resistors/AOA0000CE1.pdf; Exact price: 1; Exact price 3: 0; LastRevisionDate: 11.5.2011; Library Name: Lib_Components_SQL.DbLib; ManufacturerID: Panasonic; ManufacturerURL: http://www.industrial.panasonic.com/; Part Number: RES_000578; Part type: RES; PartNumber: RES_000578; PartQuantity: 1; PCB3D: sm_res_0402; Preference: 0; Price: 0,0700000002980232; Price 2: 0; Price 3: 0; Publishied: 11.5.2011; RoHS: 1; Simulation: res; Stock: 977; Supplier: Digikey; Supplier2: N/A; Supplier3: N/A; SupplierID: Digikey; SupplierID2: no supplier; SupplierID3: no supplier; SupplierURL: http://www.digikey.com/; SupplierURL2: N/A; SupplierURL3: N/A; Value: 0.00; LastRevisionNote: new release; Published: 11.5.2011; Publisher: Libor Polacek
L12 = RES_000578: RES 0402 0.00R SMD thick film; Manuf Part Number: ERJ-2GE0R00X; Manufacturer: Panasonic; Part Category: Thick film/0402; Part Select: SRES0001000200003100; Supp Part number: P0.0JCT-ND; Active: 1; Cat2: 0402; Database Table Name: _Resistors; DC Current: 300mA; Designer: Libor Polacek; Exact price 2: 0; FIT: 0,800000011920929; Frequency: 4.5GHz; ID: 2972; LasrRevisionNote: new release; Max Oper Temperature: 125; Min Oper Temperature: -55; PCB Status: Electrical; Resistance: 240m; Tolerance: 5%; Power: 100mW; Alternative: 1; Cat1: Thick film; Component Kind: Standard; ComponentLink1Description: Datasheet; ComponentLink1URL: https://riceproject.fel.zcu.cz/Altium/PDF/Passive/Resistors/AOA0000CE1.pdf; Exact price: 1; Exact price 3: 0; LastRevisionDate: 11.5.2011; Library Name: Lib_Components_SQL.DbLib; ManufacturerID: Panasonic; ManufacturerURL: http://www.industrial.panasonic.com/; Part Number: RES_000578; Part type: RES; PartNumber: RES_000578; PartQuantity: 1; PCB3D: sm_res_0402; Preference: 0; Price: 0,0700000002980232; Price 2: 0; Price 3: 0; Publishied: 11.5.2011; RoHS: 1; Simulation: res; Stock: 977; Supplier: Digikey; Supplier2: N/A; Supplier3: N/A; SupplierID: Digikey; SupplierID2: no supplier; SupplierID3: no supplier; SupplierURL: http://www.digikey.com/; SupplierURL2: N/A; SupplierURL3: N/A; Value: 0.00; LastRevisionNote: new release; Published: 11.5.2011; Publisher: Libor Polacek
R1 R3 R4 R7 R12 = RES_000871: RES array 0804 100R 1% 200ppm SMD thick film; Manuf Part Number: MCRE000213; Manufacturer: Multicomp; Part Category: Array/0804; Part Select: SRES0002000400003100; Supp Part number: 1711697; Active: 1; Cat2: 0804; Database Table Name: _Resistors; Designer: Jiri Cengery; Exact price 2: 0; FIT: 0,800000011920929; ID: 7908; LastRevisionNote: new release; Max Oper Temperature: 125; Min Oper Temperature: -55; PCB Status: Electrical; Power: 62.5mW; Alternative: 1; Cat1: Array; Component Kind: Standard; ComponentLink1Description: Datasheet; ComponentLink1URL: https://riceproject.fel.zcu.cz/Altium/PDF/Passive/Resistors/2861607.pdf; Exact price: 1; Exact price 3: 0; LastRevisionDate: 6.6.2017; Library Name: Lib_Components_SQL.DbLib; ManufacturerID: Multicomp; ManufacturerURL: http://www.farnell.com; Part Number: RES_000871; Part type: RES; PartNumber: RES_000871; PartQuantity: 1; Preference: 0; Price: 0,899999976158142; Price 2: 0; Price 3: 0; Published: 6.6.2017; RoHS: 1; Simulation: res; Stock: 0; Supplier: Farnell; Supplier2: N/A; Supplier3: N/A; SupplierID: Farnell; SupplierID2: no supplier; SupplierID3: no supplier; SupplierURL: http://cz.farnell.com/; SupplierURL2: N/A; SupplierURL3: N/A; Thermal Stability: 200ppm; Tolerance: 1%; Value: 100; Publisher: Jiri Cengery
R2 R6 = RES_000070: RES 0603 750R 1% 100ppm SMD thick film; Manuf Part Number: ERJ3EKF7500V; Manufacturer: Panasonic; Part Category: Thick film/0603; Part Select: SRES0001000300003750; Supp Part number: P750HTR-ND; Active: 1; Cat2: 0603; Database Table Name: _Resistors; Designer: LiPo; FIT: 0,100000001490116; ID: 431; LastRevisionNote: new release; Max Oper Temperature: 125; Min Oper Temperature: -55; PCB Status: Electrical; Power: 63mW; Alternative: 1; Cat1: Thick film; Component Kind: Standard; ComponentLink1Description: Datasheet; ComponentLink1URL: https://riceproject.fel.zcu.cz/Altium/PDF/passive\resistors\ERJ_EKF_Panasonic.pdf; Exact price: 1; LastRevisionDate: 11.5.2011; Library Name: Lib_Components_SQL.DbLib; ManufacturerID: Panasonic; ManufacturerURL: http://www.industrial.panasonic.com/; Part Number: RES_000070; Part type: RES; PartNumber: RES_000070; PartQuantity: 1; PCB3D: sm_res_0603; Price: 0,0044999998062849; Price 2: 0; Price 3: 0; Published: 11.5.2011; Quantity: 1; ROHS: 1; Simulation: res; Stock: 0; Supplier: Digikey; Supplier2: N/A; Supplier3: N/A; SupplierID: Digikey; SupplierID2: no supplier; SupplierID3: no supplier; SupplierURL: http://www.digikey.com/; SupplierURL2: N/A; SupplierURL3: N/A; Thermal Stability: 100ppm; Tolerance: 1%; Value: 750; Publisher: Libor Polacek
R5 R13 R14 = RES_000097: RES 0603 10.0k 1% 100ppm SMD thick film; Manuf Part Number: ERJ3EKF1002V; Manufacturer: Panasonic; Part Category: Thick film/0603; Part Select: SRES0001000300005100; Supp Part number: P10.0kHTR-ND; Supp Part number 2: 667-ERJ-3EKF1002V; Active: 1; Cat2: 0603; Database Table Name: _Resistors; Designer: Tomáš Košan; Exact price 2: 0; FIT: 0,100000001490116; ID: 458; LastRevisionNote: new release; Max Oper Temperature: 125; Min Oper Temperature: -55; PCB Status: Electrical; Power: 63mW; Alternative: 1; Cat1: Thick film; Component Kind: Standard; ComponentLink1Description: Datasheet; ComponentLink1URL: https://riceproject.fel.zcu.cz/Altium/PDF/passive\resistors\ERJ_EKF_Panasonic.pdf; Exact price: 1; Exaxt price 3: 0; LastRevisionDate: 11.5.2011; Library Name: Lib_Components_SQL.DbLib; ManufacturerID: Panasonic; ManufacturerURL: http://www.industrial.panasonic.com/; Part Number: RES_000097; Part type: RES; PartNumber: RES_000097; PartQuantity: 1; PCB3D: sm_res_0603; Preference: 0; Price: 0,0044999998062849; Price 2: 2,4300000667572; Price 3: 0; Published: 11.5.2011; Quantity: 1; ROHS: 1; Simulation: res; Stock: 985; Supplier: Digikey; Supplier2: Mouser Electronics; Supplier3: N/A; SupplierID: Digikey; SupplierID2: Mouser; SupplierID3: no supplier; SupplierURL: http://www.digikey.com/; SupplierURL2: www.mouser.com; SupplierURL3: N/A; Thermal Stability: 100ppm; Tolerance: 1%; Value: 10.0k; Publisher: Libor Polacek
R8-R11 = RES_000049: RES 0603 100R 1% 100ppm SMD thick film; Manuf Part Number: ERJ3EKF1000V; Manufacturer: Panasonic; Part Category: Thick film/0603; Part Select: SRES0001000300003100; Supp Part number: P100HTR-ND; Active: 1; Cat2: 0603; Database Table Name: _Resistors; Designer: LiPo; FIT: 0,100000001490116; ID: 410; LastRevisionNote: new release; Max Oper Temperature: 125; Min Oper Temperature: -55; PCB Status: Electrical; Power: 63mW; Alternative: 1; Cat1: Thick film; Component Kind: Standard; ComponentLink1Description: Datasheet; ComponentLink1URL: https://riceproject.fel.zcu.cz/Altium/PDF/passive/resistors/ERJ_EKF_Panasonic.pdf; Exact price: 1; LastRevisionDate: 11.5.2011; Library Name: Lib_Components_SQL.DbLib; ManufacturerID: Panasonic; ManufacturerURL: http://www.industrial.panasonic.com/; Part Number: RES_000049; Part type: RES; PartNumber: RES_000049; PartQuantity: 1; PCB3D: sm_res_0603; Price: 0,0044999998062849; Price 2: 0; Price 3: 0; Published: 11.5.2011; Quantity: 1; RoHS: 1; Simulation: res; Stock: 7300; Supplier: Digikey; Supplier2: N/A; Supplier3: N/A; SupplierID: Digikey; SupplierID2: no supplier; SupplierID3: no supplier; SupplierURL: http://www.digikey.com/; SupplierURL2: N/A; SupplierURL3: N/A; Thermal Stability: 100ppm; Tolerance: 1%; Value: 100; Publisher: Libor Polacek
S1 = MCH_000104: Solder jumper 2pin; simple type; ManufacturerID: no manufacturer; Part Category: Virtual/Pattern; Part Select: SMCH0002000300000000; Active: 1; Cat1: Virtual; Cat2: Pattern; Database Table Name: _Mechanicals; Designer: Jiri  Cengery; Exact price 2: 0; FIT: 9,99999974737875E-6; Function Type: Solder jumper 3PIN; ID: 5870; Max Oper Temperature: 125; Min Oper Temperature: -45; Value: Solder jumper 3PIN small; Alternative: 1; ComponentLink1Description: Datasheet; Exact price: 1; Exaxt price 3: 0; LatestRevisionDate: 22.1.2012; LatestRevisionNote: new release; Library Name: Lib_Components_SQL.DbLib; Part Number: MCH_000104; Part type: MCH; PartNumber: MCH_000104; PartQuantity: 1; PCB Status: Virtual; Preference: 0; Price: 0; Price 2: 0; Price 3: 0; Published: 22.1.2012; ROHS: 1; Simulation: nosim; Stock: 0; Supplier: N/A; Supplier2: N/A; Supplier3: N/A; SupplierID: no supplier; SupplierID2: no supplier; SupplierID3: no supplier; SupplierURL: N/A; SupplierURL2: N/A; SupplierURL3: N/A; Publisher: Tomas Kosan
SW1 SW2 = SWI_000034: SWI Tactile Switch SPST-NO Side Actuated Surface Mount, travel 0.-2 mm, Right Angle; Manufacturer: Panasonic; Part Category: Button/Closing; Part Select: SSWI0002000100000000; Supp Part number: 2860918; Supp Part number 2: 667-EVQP7J01P; Active: 1; Cat1: Button; Cat2: Closing; Database Table Name: _Switches; Designer: Jiri Cengery; Exact price 3: 0; FIT: 3; ID: 7642; Max Oper Temperature: 70; Min Oper Temperature: -20; PCB Status: Electrical; Alternative: 1; Component Kind: Standard; ComponentLink1Description: Datasheet; ComponentLink1URL: https://riceproject.fel.zcu.cz/Altium/PDF/Passive/Switches/2609099.pdf; Design: button; Exact price: 1; Exact price 2: 1; LatestRevisionDate: 17-Jul-2002; LatestRevisionNote: Re-released for DXP Platform.; Library Name: Lib_Components_SQL.DbLib; Manuf Part Number: EVQP7J01P; ManufacturerID: Panasonic; ManufacturerURL: http://www.industrial.panasonic.com/; Part Number: SWI_000034; Part type: SWI; PartNumber: SWI_000034; PartQuantity: 1; Preference: 0; Price: 4,30000019073486; Price 2: 7; Price 3: 0; Published: 24-Mar-1999; RoHS: 1; Simulation: nosim; Stock: 0; Supplier: Farnell; Supplier2: Mouser Electronics; Supplier3: N/A; SupplierID: Farnell; SupplierID2: Mouser; SupplierID3: no supplier; SupplierURL: http://cz.farnell.com/; SupplierURL2: http://www.mouser.com; SupplierURL3: N/A; Value: EVQP7J01P; Publisher: Altium Limited
TP1 TP3 = MCH_000001: Test point 1mm; ManufacturerID: no manufacturer; Part Category: Virtual/Test point; Part Select: SMCH0002000100000000; Active: 1; Cat1: Virtual; Database Table Name: _Mechanicals; Designer: Libor Polacek; FIT: 9,99999974737875E-6; ID: 887; LastRevisionNote: new release; Max Oper Temperature: 200; Min Oper Temperature: -60; Preference: 0; Alternative: 1; Cat2: Test point; ComponentLink1Description: Datasheet; Exact price: 1; Function Type: Test point; LastRevisionDate: 11.5.2011; Library Name: Lib_Components_SQL.DbLib; Part Number: MCH_000001; Part type: MCH; PartNumber: MCH_000001; PartQuantity: 1; PCB Status: Virtual; Price: 0; Price 2: 0; Price 3: 0; Publishied: 11.5.2011; Quantity: 1; RoHS: 1; Simulation: nosim; Stock: 0; Supplier: N/A; Supplier2: N/A; Supplier3: N/A; SupplierID: no supplier; SupplierID2: no supplier; SupplierID3: no supplier; SupplierURL: N/A; SupplierURL2: N/A; SupplierURL3: N/A; Value: TP40; Publisher: Libor Polacek
U1 = ICS_001095: ICS transceiver 137 - 1020 MHz, FSK, GFSK, MSK, GMSK, LoRa and OOK modulation, out power 20dBm, sensitivity -148dBm; Manuf Part Number: SX1276IMLTRT; Manufacturer: Semtech; Part Category: Digital/Transceiver; Part Select: SICS0002001700000000; Supp Part number: 3274241; Supp Part number 2: 947-SX1276IMLTRT; Active: 1; Cat1: Digital; Database Table Name: _ICs; Designer: Jiri Cengery; Exact price 3: 0; FIT: 5; Function Type: RF Transceiver; ID: 7888; LastRevisionNote: new release; Max Oper Temperature: 85; Min Oper Temperature: -40; PCB Status: Electrical; Alternative: 1; Cat2: Transceiver; Component Kind: Standard; ComponentLink1Description: Datasheet; ComponentLink1URL: https://riceproject.fel.zcu.cz/Altium/PDF/Semiconductor/Analog/Transceiver/2735936.pdf; Exact price: 1; Exact price 2: 1; LastRevisionDate: 14.4.2021; Library Name: Lib_Components_SQL.DbLib; ManufacturerID: Semtech; ManufacturerURL: http://www.semtech.com/; Part Number: ICS_001095; Part type: ICS; PartNumber: ICS_001095; PartQuantity: 1; Preference: 0; Price: 170; Price 2: 170; Price 3: 0; Published: 14.4.2021; RoHS: 1; Simulation: nosim; Stock: 0; Supplier: Farnell; Supplier2: Mouser Electronics; Supplier3: N/A; SupplierID: Farnell; SupplierID2: Mouser; SupplierID3: no supplier; SupplierURL: http://cz.farnell.com/; SupplierURL2: http://www.mouser.com; SupplierURL3: N/A; Value: SX1276; Publisher: Jiri Cengery
U3 = CMP-12105-000008-1: IC MCU 32BIT 128KB FLASH 48LQFP; Manufacturer: STMicroelectronics; Core Architecture: Arm Cortex-M0+; Data Bus Width: 32bits; Frequency: 32MHz; Interface: I2C,SPI,UART,USART,USB,IrDA; Max Junction Temp: +105°C; Max Supply Voltage: 3.6V; Memory Size: 128KBytes; Min Supply Voltage: 1.8V; Number Of A/D Converters: 1; Number Of Adc Channels: 8; Number Of I2C Channels: 3; Number Of I/Os: 37; Number Of Spi Channels: 4; Number Of Timers/Counters: 9; Number Of Uart Channels: 5; Ram Size: 20KBytes; Standoff Height: 0.05mm; Ambient Temperature Range High: +85°C; Ambient Temperature Range Low: -40°C; Automotive: No; Category: IC; Component Kind: Standard; ComponentLink2Description: Datasheet; ComponentLink2URL: https://www.st.com/content/ccc/resource/technical/document/datasheet/98/e1/71/c0/ae/f5/4b/d4/DM00141133.pdf/files/DM00141133.pdf/jcr:content/translations/en.DM00141133.pdf; Device Class L1: Integrated Circuits (ICs); Device Class L2: Embedded Processors and Controllers; Device Class L3: Microcontrollers; Digikey Description: IC MCU 32BIT 128KB FLASH 48LQFP; Height: 1.6mm; Lead Free: Yes; Library Name: Altium Content Vault; Manuf Part Number: STM32L072CZT6TR; Manufacturer Part Number: STM32L072CZT6TR; Memory Type: FLASH; Number Of Cores: 1; Number Of Usb Channels: 1; Octopart: https://octopart.com/stm32l072cbt6-stmicroelectronics-71108084; Package: LQFP48-5B; RoHS: TRUE; Temperature: -40°C to +85°C; Temperature Range High: +85°C; Temperature Range Low: -40°C
